# Altium schematic sheet Zombee_1.SchDoc
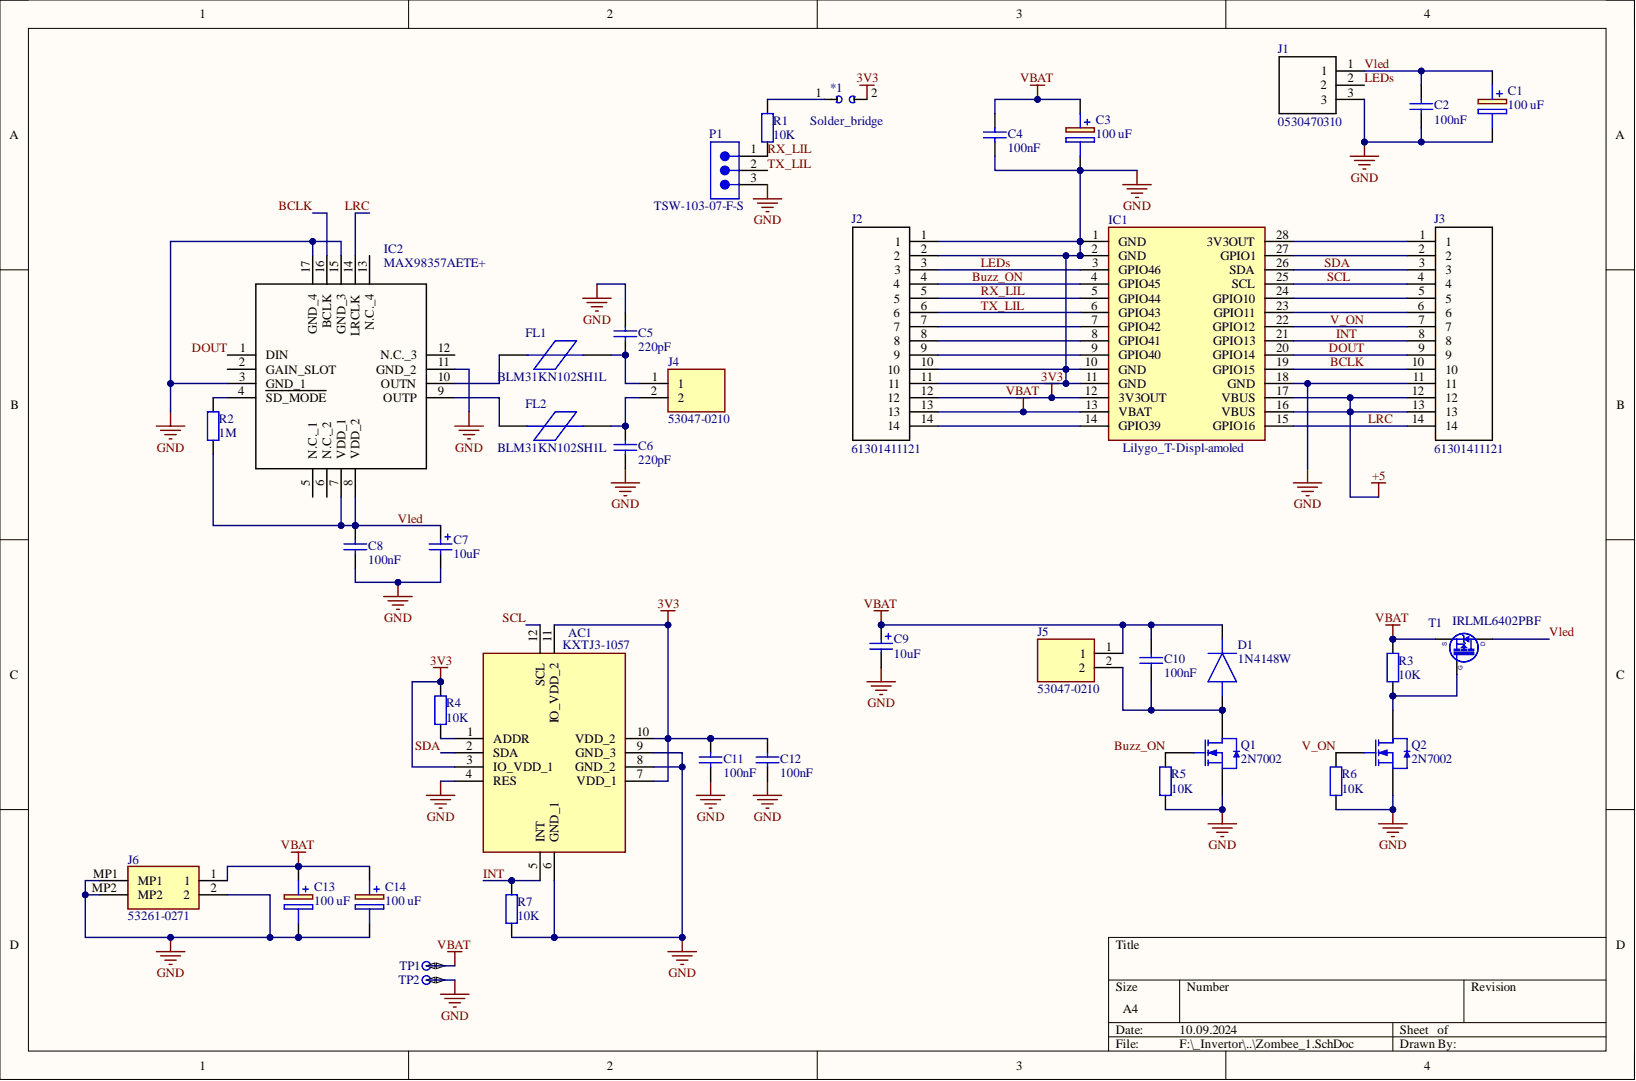
[diagram p0: Zombee_1.SchDoc]

## Parts
*1  Solder_bridge  [Solder_bridge]
AC1  KXTJ3-1057  [2X2X0.9MMLGA]
C1  value 100 uF  [CAPPM3528X210N]  (hidden comment: TAJB107K010RNJ)
C2  100nF  [0603_C]
C3  value 100 uF  [CAPPM3528X210N]  (hidden comment: TAJB107K010RNJ)
C4  100nF  [0603_C]
C5  220pF  [0603_C]
C6  220pF  [0603_C]
C7  10uF  [CASE-A_3216]
C8  100nF  [0603_C]
C9  10uF  [CASE-A_3216]
C10  100nF  [0603_C]
C11  100nF  [0603_C]
C12  100nF  [0603_C]
C13  value 100 uF  [CAPPM3528X210N]  (hidden comment: TAJB107K010RNJ)
C14  value 100 uF  [CAPPM3528X210N]  (hidden comment: TAJB107K010RNJ)
D1  1N4148W  [SOD3716X125N]
FL1  BLM31KN102SH1L  [BLM31KN102BH1L]
FL2  BLM31KN102SH1L  [BLM31KN102BH1L]
IC1  Lilygo_T-Displ-amoled  [lILYGO_t-fISPL_NOCONN]
IC2  MAX98357AETE+  [QFN50P300X300X80-17N]
J1  0530470310  [0530470360]
J2  61301411121  [HDRV14W64P0X254_1X14_3556X254X854P]
J3  61301411121  [HDRV14W64P0X254_1X14_3556X254X854P]
J4  53047-0210  [530470210]
J5  53047-0210  [530470210]
J6  53261-0271  [532610271]
P1  TSW-103-07-F-S  [SMTC-TSW-103-07-X-S]
Q1  2N7002  [SOT-23(SOT-23-3)]
Q2  2N7002  [SOT-23(SOT-23-3)]
R1  10K  [0603_R]
R2  1M  [0603_R]
R3  10K  [0603_R]
R4  10K  [0603_R]
R5  10K  [0603_R]
R6  10K  [0603_R]
R7  10K  [0603_R]
T1  IRLML6402PBF  [SOT95P237X112-3N]
TP1  Test Point  [TPS127]  (value not drawn)  (no BOM)
TP2  Test Point  [TPS127]  (value not drawn)  (no BOM)

## Nets
3V3: *1.2 AC1.3(IO_VDD_1) AC1.7(VDD_1) AC1.10(VDD_2) AC1.11(IO_VDD_2) C11.2 C12.2 IC1.12(3V3OUT) J2.12 R4.2
+5: IC1.16(VBUS) IC1.17(VBUS) J3.12 J3.13
GND: AC1.4(RES) AC1.6(GND_1) AC1.8(GND_2) AC1.9(GND_3) C1.2 C2.1 C3.2 C4.1 C5.2 C6.1 C7.2 C8.1 C9.2 C11.1 C12.1 C13.2 C14.2 IC1.1(GND) IC1.2(GND) IC1.10(GND) IC1.11(GND) IC1.18(GND) IC2.3(GND_1) IC2.11(GND_2) IC2.15(GND_3) IC2.17(GND_4) J1.3 J2.1 J2.2 J2.10 J2.11 J3.11 J6.2 J6.MP1 J6.MP2 P1.3 Q1.2 Q2.2 R5.1 R6.1 R7.1 TP2.1
VBAT: C3.1 C4.2 C9.1 C10.2 C13.1 C14.1 D1.1 IC1.13(VBAT) J2.13 J5.1 J6.1 R3.2 T1.2 TP1.1
BCLK: IC1.19(GPIO15) IC2.16(BCLK) J3.10
Buzz_ON: IC1.4(GPIO45) J2.4 Q1.1 R5.2
DOUT: IC1.20(GPIO14) IC2.1(DIN) J3.9
INT: AC1.5(INT) IC1.21(GPIO13) J3.8 R7.2
LEDs: IC1.3(GPIO46) J1.2 J2.3
LRC: IC1.15(GPIO16) IC2.14(LRCLK) J3.14
RX_LIL: IC1.5(GPIO44) J2.5 P1.1 R1.1
SCL: AC1.12(SCL) IC1.25(SCL) J3.4
SDA: AC1.2(SDA) IC1.26(SDA) J3.3
TX_LIL: IC1.6(GPIO43) J2.6 P1.2
V_ON: IC1.22(GPIO12) J3.7 Q2.1 R6.2
Vled: C1.1 C2.2 C7.1 C8.2 IC2.7(VDD_1) IC2.8(VDD_2) J1.1 R2.1 T1.3
Net*1_1: *1.1 R1.2
NetAC1_1: AC1.1(ADDR) R4.1
NetC5_1: C5.1 FL1.2 J4.1
NetC6_2: C6.2 FL2.2 J4.2
NetC10_1: C10.1 D1.2 J5.2 Q1.3
NetFL1_1: FL1.1 IC2.10(OUTN)
NetFL2_1: FL2.1 IC2.9(OUTP)
NetIC1_7: IC1.7(GPIO42) J2.7
NetIC1_8: IC1.8(GPIO41) J2.8
NetIC1_9: IC1.9(GPIO40) J2.9
NetIC1_14: IC1.14(GPIO39) J2.14
NetIC1_23: IC1.23(GPIO11) J3.6
NetIC1_24: IC1.24(GPIO10) J3.5
NetIC1_27: IC1.27(GPIO1) J3.2
NetIC1_28: IC1.28(3V3OUT) J3.1
NetIC2_4: IC2.4(SD_MODE) R2.2
NetQ2_3: Q2.3 R3.1 T1.1

Not connected: IC2 2(GAIN_SLOT) 5(N.C._1) 6(N.C._2) 12(N.C._3) 13(N.C._4)

## Library data of the parts
AC1 = KXTJ3-1057: Accelerometer; Manufacturer_Name: Kionix; Component Kind: Standard; Datasheet Link: http://www.mouser.com/ds/2/348/KXTJ3-1057 Specifications Rev 2.0-1074602.pdf; Library Name: SamacSys.SchLib; Manufacturer_Part_Number: KXTJ3-1057; Mouser Part Number: 912-KXTJ3-1057; Mouser Price/Stock: https://www.mouser.co.uk/ProductDetail/Kionix/KXTJ3-1057?qs=hicmRmzGcNR8WipH%252BLEjqg%3D%3D
C1 C3 C14 = TAJB107K010RNJ: Capacitor Polarised; Manufacturer_Name: AVX; Arrow Part Number: TAJB107K010RNJ; Arrow Price/Stock: https://www.arrow.com/en/products/tajb107k010rnj/avx; Component Kind: Standard; Datasheet Link: http://datasheets.avx.com/TAJ.pdf; Height: 2.1mm; Library Name: SamacSys.SchLib; Manufacturer_Part_Number: TAJB107K010RNJ; Mouser Part Number: 581-TAJB107K010RNJ; Mouser Price/Stock: https://www.mouser.co.uk/ProductDetail/AVX/TAJB107K010RNJ?qs=q%252BHV0zBR8Mg2k4U4NZgkMQ%3D%3D
C2 C4 C8 C10-C12 = 0603 100nF (104) 10% 50V X7R: Chip capacitors; Manufacturer: Samsung Electro-Mechanical; PartNumber: CL10B104KB8NNWC; Tolerance: 10%; Voltage: 50V; Capacitance: 100nF; Component Kind: Standard; ComponentLink1Description: Supplier link; ComponentLink1URL: http://www.szlcsc.com/product/details_15331.html; Library Name: Cap.SchLib; Package: 0603
C5 C6 = 0603 220pF (221) 10% 50V: Chip capacitors; Manufacturer: Samsung Electro-Mechanics; PartNumber: CL10B221KB8NNNC; Tolerance: 10%; Voltage: 50V; Capacitance: 220pF; Component Kind: Standard; ComponentLink1Description: Supplier link; ComponentLink1URL: http://www.szlcsc.com/product/details_1955.html; Library Name: Cap.SchLib; Package: 0603; Supplier: LC; SuppliersPartNumber: C1603
C7 C9 = 3216 10uF (106) 10% 16V: Tantalum capacitor; Manufacturer: AVX; PartNumber: TAJA106K016RNJ; Tolerance: 10%; Voltage: 16V; Capacitance: 10uF; Component Kind: Standard; ComponentLink1Description: Supplier link; ComponentLink1URL: http://www.szlcsc.com/product/details_7643.html; Library Name: Cap.SchLib; Package: 3216; Supplier: LC; SuppliersPartNumber: C7171
C13 = TAJB107K010RNJ: Capacitor Polarised; Manufacturer_Name: AVX; Value: 100 uF; Arrow Part Number: TAJB107K010RNJ; Arrow Price/Stock: https://www.arrow.com/en/products/tajb107k010rnj/avx; Component Kind: Standard; Datasheet Link: http://datasheets.avx.com/TAJ.pdf; Height: 2.1mm; Library Name: SamacSys.SchLib; Manufacturer_Part_Number: TAJB107K010RNJ; Mouser Part Number: 581-TAJB107K010RNJ; Mouser Price/Stock: https://www.mouser.co.uk/ProductDetail/AVX/TAJB107K010RNJ?qs=q%252BHV0zBR8Mg2k4U4NZgkMQ%3D%3D
D1 = 1N4148W: Diode; Manufacturer_Name: Rectron; Arrow Part Number: 1N4148W; Arrow Price/Stock: https://www.arrow.com/en/products/1n4148w/rectron?region=nac; Component Kind: Standard; Datasheet Link: https://www.rectron.com/public/product_datasheets/1n4148w.pdf; Height: 1.25mm; Library Name: SamacSys.SchLib; Manufacturer_Part_Number: 1N4148W; Mouser Part Number: 583-1N4148W; Mouser Price/Stock: https://www.mouser.co.uk/ProductDetail/Rectron/1N4148W?qs=rrS6PyfT74dlwuw0ST2zKA%3D%3D
FL1 FL2 = BLM31KN102SH1L: Filter; Manufacturer_Name: Murata Electronics; Arrow Part Number: BLM31KN102SH1L; Arrow Price/Stock: https://www.arrow.com/en/products/blm31kn102sh1l/murata-manufacturing?region=nac; Component Kind: Standard; Datasheet Link: https://www.murata.com/en-us/products/productdetail?partno=BLM31KN102SH1%23; Height: 1.8mm; Library Name: SamacSys.SchLib; Manufacturer_Part_Number: BLM31KN102SH1L; Mouser Part Number: 81-BLM31KN102SH1L; Mouser Price/Stock: https://www.mouser.co.uk/ProductDetail/Murata-Electronics/BLM31KN102SH1L?qs=EU6FO9ffTwdyuRCj%252BudO3g%3D%3D
IC1 = Lilygo_T-Displ-amoled: Integrated Circuit; Manufacturer_Name: Lilygo; Component Kind: Standard; Height: 10mm; Library Name: SamacSys.SchLib
IC2 = MAX98357AETE+: Integrated Circuit; Manufacturer_Name: Analog Devices; Component Kind: Standard; Datasheet Link: https://datasheets.maximintegrated.com/en/ds/MAX98357A-MAX98357B.pdf; Height: 0.8mm; Manufacturer_Part_Number: MAX98357AETE+; Mouser Part Number: 700-MAX98357AETE+; Mouser Price/Stock: https://www.mouser.co.uk/ProductDetail/Analog-Devices-Maxim-Integrated/MAX98357AETE%2b?qs=AAveGqk956EyS6m3AmZeuw%3D%3D
J1 = 0530470310: Connector; Manufacturer_Name: Molex; Component Kind: Standard; Datasheet Link: https://www.molex.com/pdm_docs/sd/530470310_sd.pdf; Height: 4.4mm; Library Name: SamacSys.SchLib; Manufacturer_Part_Number: 0530470310
J2 J3 = 61301411121: Connector; Manufacturer_Name: Wurth Elektronik; Component Kind: Standard; Datasheet Link: http://katalog.we-online.de/em/datasheet/6130xx11121.pdf; Height: 8.54mm; Manufacturer_Part_Number: 61301411121; Mouser Part Number: 710-61301411121; Mouser Price/Stock: https://www.mouser.co.uk/ProductDetail/Wurth-Elektronik/61301411121?qs=PhR8RmCirEarafZjB85bSA%3D%3D
J4 J5 = 53047-0210: Connector; Manufacturer_Name: Molex; Component Kind: Standard; Datasheet Link: https://componentsearchengine.com/Datasheets/2/53047-0210.pdf; Height: 4.4mm; Manufacturer_Part_Number: 53047-0210; Mouser Part Number: 538-53047-0210; Mouser Price/Stock: https://www.mouser.co.uk/ProductDetail/Molex/53047-0210?qs=M5Ic86%252BuP8b8FQrTwriyOw%3D%3D
J6 = 53261-0271: Connector; Manufacturer_Name: Molex; Arrow Part Number: 53261-0271; Arrow Price/Stock: https://www.arrow.com/en/products/53261-0271/molex?region=nac; Component Kind: Standard; Datasheet Link: https://www.molex.com/pdm_docs/sd/532610271_sd.pdf; Height: 3.65mm; Library Name: SamacSys.SchLib; Manufacturer_Part_Number: 53261-0271; Mouser Part Number: 538-53261-0271; Mouser Price/Stock: https://www.mouser.co.uk/ProductDetail/Molex/53261-0271?qs=%252B72YyncTwW%252B8%252BBjraxGf3A%3D%3D
P1 = TSW-103-07-F-S: 0.025" SQ Post Header, Through-hole, Vertical, -55 to 125 degC, 2.54 mm Pitch, 3-Pin, Male, RoHS; Manufacturer: Samtec; Pitch: 2.54 mm; Component Kind: Standard; ComponentLink1Description: Manufacturer URL; ComponentLink1URL: http://www.samtec.com; ComponentLink2Description: Datasheet; ComponentLink2URL: http://www.samtec.com/documents/webfiles/pdf/TSW_TH.PDF; ComponentLink3Description: Package Specification; ComponentLink3URL: http://www.samtec.com/documents/webfiles/cpdf/TSW-XXX-XX-XXX-X-XX-XXX-MKT.pdf; DatasheetVersion: F-214; Mounting Technology: Through-hole; Orientation: Vertical; PackageDescription: .025[0.64] SQ. Post Terminal Strip Assembly; PackageReference: TSW-103-07-X-S; PackageVersion: DM, 8/1988; PartNumber: TSW-103-07-F-S; RoHS: True; Supplier 1: Digi-Key; Supplier Part Number 1: TSW-103-07-F-S-ND; Temperature Range: -55 to 125 degC; Library Name: Samtec_2,54_mm_Pitch_Terminal_Strips.IntLib
Q1 Q2 = 2N7002 7002: MOSFET n-channel; Component Kind: Standard; ComponentLink1Description: 供应商链接; ComponentLink1URL: http://www.szlcsc.com/product/details_9040.html; Library Name: MOS.SchLib; Package: SOT-23(SOT-23-3); Supplier: LC; SuppliersPartNumber: C8545
R1 R3-R7 = 0603 10K (1002) 1%: Chip resistor; Manufacturer: Uniroyal Elec; PartNumber: 0603WAF1002T5E; Power: 1/10W; Resistance: 10KΩ; Tolerance: 1%; Component Kind: Standard; ComponentLink1Description: Supplier link; ComponentLink1URL: http://www.szlcsc.com/product/details_26547.html; Library Name: RES.SchLib; Package: 0603; Supplier: LC; SuppliersPartNumber: C25804
R2 = 0603 1M (1004) 1%: Chip resistor; Manufacturer: Uniroyal Elec; PartNumber: 0603WAF1004T5E; Power: 1/10W; Resistance: 1MΩ; Tolerance: 1%; Component Kind: Standard; ComponentLink1Description: Supplier link; ComponentLink1URL: http://www.szlcsc.com/product/details_23662.html; Library Name: RES.SchLib; Package: 0603; Supplier: LC; SuppliersPartNumber: C22935
T1 = IRLML6402PBF: P-CHANNEL MOSFET WITH ZENER DIODE; Manufacturer: INTERNATIONAL RECTIFIER; Part Description: -20V -3.7A P-Channel HEXFET® Power MOSFET; Bonding: No; Database Table Name: Transistors; PressFit: No; Case: SOT23-3; Component Kind: Standard; Component Type: Standard; ComponentHeight: 1.12mm; CreateDate: 29.08.2011; Device: IRLML6402PBF; HelpURL: \\cern.ch\dfs\Applications\Altium\Datasheets\IRLML6402PBF.pdf; LatestRevisionDate: 29.08.2011; Library Name: ICs And Semiconductors.DbLib; Manufacturer Part Number: IRLML6402PBF; Mounted: Yes; PackageDescription: SOT, 0.95mm, pitch; 3 pin,1.30mm W X 2.92mm L X 1.12mm H body, IPC Medium Density; Part Number: IRLML6402PBF; Pin Count: 3; Sense: No; SMD: Yes; Socket: No; SuppliersPartNumber: C2593; Author: CERN DEM JLC
TP1 TP2 = SMD_PAD_1.27X1.27MM: Part Description: 1.27mm x 1.27mm PCB Copper Area (SMD Test Point); Database Table Name: Pads; Mounted: No; Component Type: Standard (No BOM); ComponentHeight: 0mm; CreateDate: 12.01.2008; Description: Test Point; Family: Test Point; HelpURL: Undefined; LatestRevisionDate: 12.01.2008; Library Name: Eletro-mechanical.DbLib; PackageDescription: 1.27mm x 1.27mm PCB Copper Area (SMD Test Point); Part Number: SMD_PAD_1.27X1.27MM; Pin Count: 1; Sense: No; SMD: Yes; Socket: No; Status: None; Author: CERN DEM JLC
*1 = Solder_bridge: Component Kind: Standard; Library Name: SamacSys.SchLib
